# Altium schematic sheet EIT_BLE.SchDoc
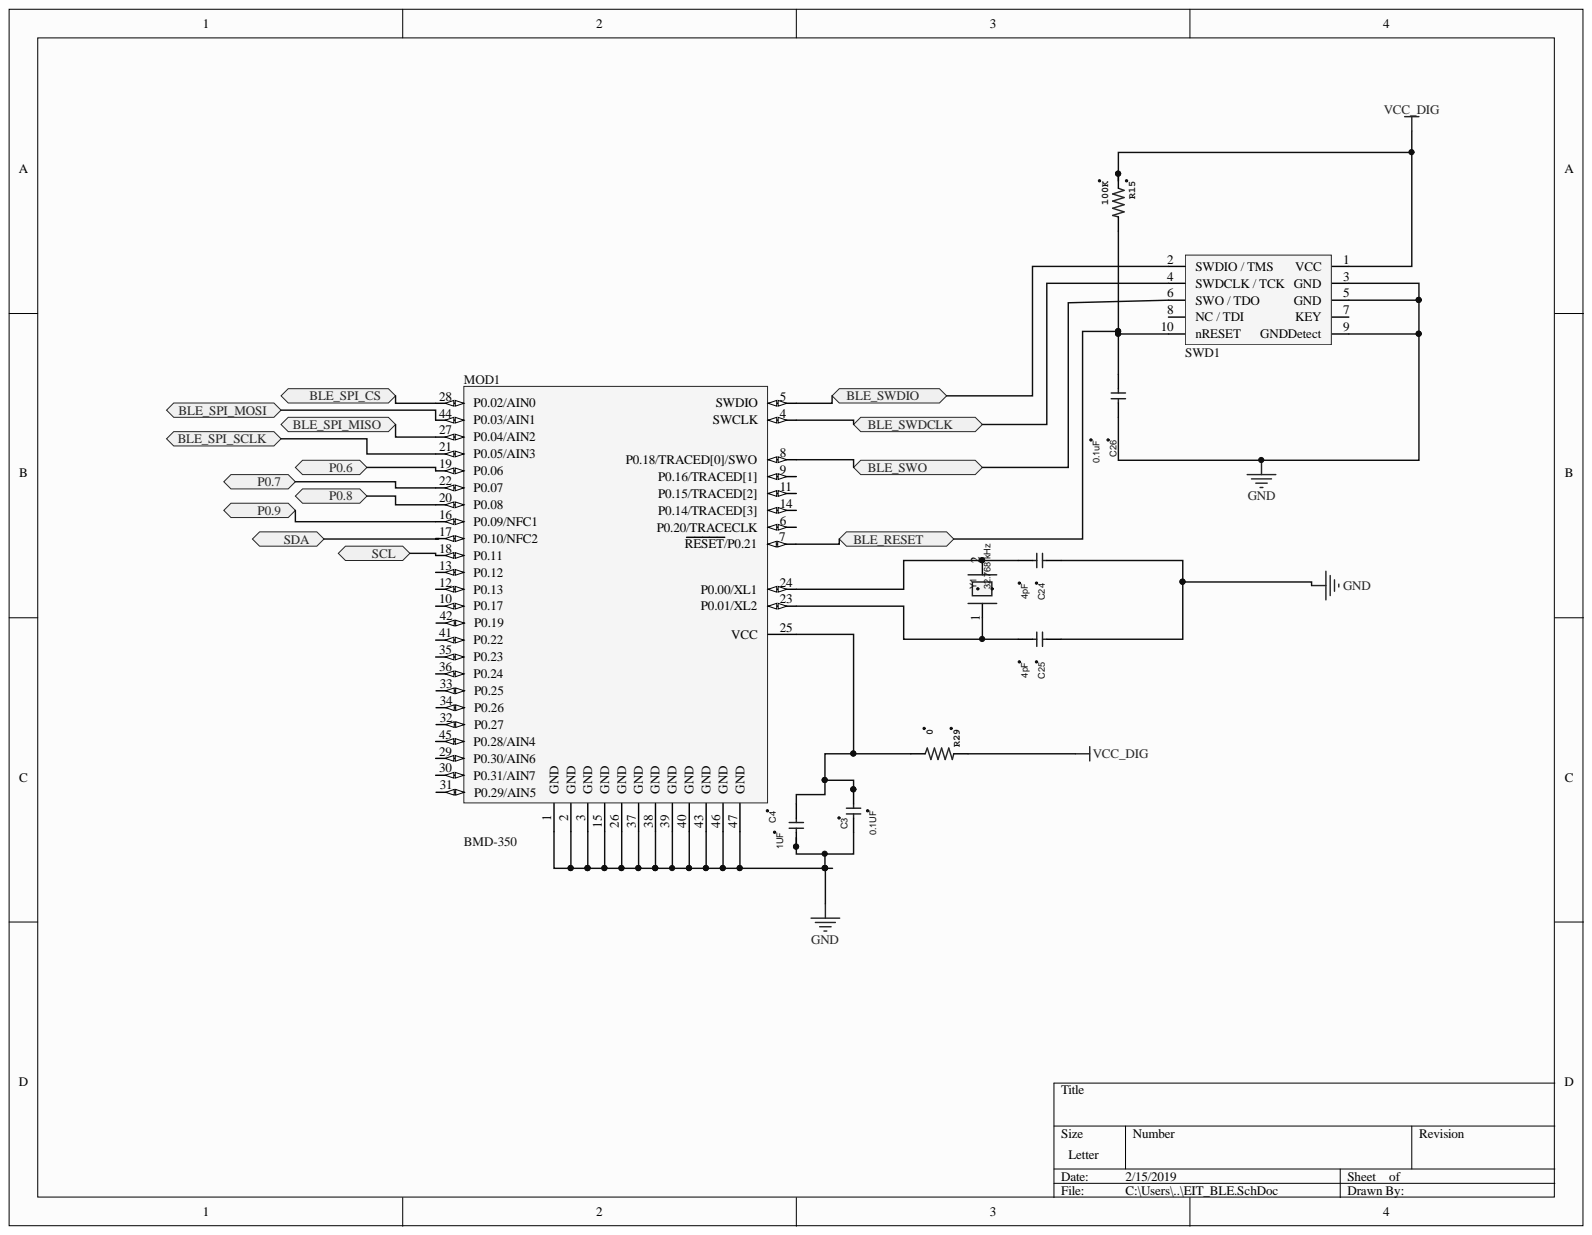
[diagram p0: EIT_BLE.SchDoc]

## Parts
C3  0.1UF  [CAP 0402/1005]
C4  1UF  [CAP 0402/1005]
C24  4pF  [CAP 0402/1005]
C25  4pF  [CAP 0402/1005]
C26  0.1uF  [CAP_0603]
MOD1  BMD-350  [BMD-350]
R15  100K  [RES_1608X55]
R29  0  [RES_1608X55]
SWD1  SWD Header THRU  [2X5-CONN_1.27MM]  (value not drawn)
Y1  32.768 kHz  [XTAL2-2P0X1P2-SM]

## Ports
BLE_RESET, BLE_SPI_CS, BLE_SPI_MISO, BLE_SPI_MOSI, BLE_SPI_SCLK, BLE_SWDCLK, BLE_SWDIO, BLE_SWO, P0.6, P0.7, P0.8, P0.9, SCL, SDA

## Nets
GND: C3.1 C4.1 C24.2 C25.2 C26.1 MOD1.1(GND) MOD1.2(GND) MOD1.3(GND) MOD1.15(GND) MOD1.26(GND) MOD1.37(GND) MOD1.38(GND) MOD1.39(GND) MOD1.40(GND) MOD1.43(GND) MOD1.46(GND) MOD1.47(GND) SWD1.3(GND) SWD1.5(GND) SWD1.9(GNDDetect)
VCC_DIG: R15.2 R29.1 SWD1.1(VCC)
BLE_RESET: C26.2 MOD1.7(RESET/P0.21) R15.1 SWD1.10(nRESET) ; port BLE_RESET
BLE_SPI_CS: MOD1.28(P0.02/AIN0) ; port BLE_SPI_CS
BLE_SPI_MISO: MOD1.27(P0.04/AIN2) ; port BLE_SPI_MISO
BLE_SPI_MOSI: MOD1.44(P0.03/AIN1) ; port BLE_SPI_MOSI
BLE_SPI_SCLK: MOD1.21(P0.05/AIN3) ; port BLE_SPI_SCLK
BLE_SWDCLK: MOD1.4(SWCLK) SWD1.4 ; port BLE_SWDCLK
BLE_SWDIO: MOD1.5(SWDIO) SWD1.2 ; port BLE_SWDIO
BLE_SWO: MOD1.8(P0.18/TRACED[0]/SWO) SWD1.6(SWO_/_TDO) ; port BLE_SWO
P0.6: MOD1.19(P0.06) ; port P0.6
P0.7: MOD1.22(P0.07) ; port P0.7
P0.8: MOD1.20(P0.08) ; port P0.8
P0.9: MOD1.16(P0.09/NFC1) ; port P0.9
SCL: MOD1.18(P0.11) ; port SCL
SDA: MOD1.17(P0.10/NFC2) ; port SDA
NetC3_2: C3.2 C4.2 MOD1.25(VCC) R29.2
NetC24_1: C24.1 MOD1.24(P0.00/XL1) Y1.2
NetC25_1: C25.1 MOD1.23(P0.01/XL2) Y1.1

Not connected: MOD1 6(P0.20/TRACECLK) 9(P0.16/TRACED[1]) 10(P0.17) 11(P0.15/TRACED[2]) 12(P0.13) 13(P0.12) 14(P0.14/TRACED[3]) 29(P0.30/AIN6) 30(P0.31/AIN7) 31(P0.29/AIN5) 32(P0.27) 33(P0.25) 34(P0.26) 35(P0.23) 36(P0.24) 41(P0.22) 42(P0.19) 45(P0.28/AIN4); SWD1 7(KEY) 8(NC_/_TDI)

## Library data of the parts
C3 = CAP_0402_0.1UF: CAP CER 0.1UF 4V X5R 0402 10% tolerance; Manufacturer 1: KEMET; Manufacturer Part Number 1: C0402C104K7PAC7867; Vendor: DIGIKEY; Component Kind: Standard; Supplier 1: Digi-Key; Supplier Part Number 1: 399-14871-1-ND; Value: 0.1UF; Library Name: EIT_lib.SchLib
C4 = CAP_0402_1UF: CAP CER 1UF 4V X5R 0402; Manufacturer 1: TAIYO YUDEN; Manufacturer Part Number 1: AMK105BJ105ME-R; Vendor: DIGIKEY; Component Kind: Standard; Supplier 1: Digi-Key; Supplier Part Number 1: 587-4554-6-ND; Value: 1UF; Library Name: EIT_lib.SchLib
C24 C25 = CAP_0402_4pF: CAP CER 4PF 100V C0G/NP0 0402; Manufacturer 1: SAMSUNG ELETROMECHANICS; Manufacturer Part Number 1: CL05C040CC5NNNC; Vendor: DIGIKEY; Component Kind: Standard; Supplier 1: Digi-Key; Supplier Part Number 1: 1276-6708-6-ND; Value: 4pF; Library Name: EIT_lib.SchLib
C26 = CAP_0603_0.1uF: CAP CER 0.1UF 6.3V 20% X5R 0603; Manufacturer 1: AVX Corporation; Manufacturer Part Number 1: 06036D104KAT2A; Vendor: DIGIKEY; VendorPN: 399-1095-1-ND; Component Kind: Standard; Supplier 1: Digi-Key; Value: 0.1uF; Library Name: EIT_lib.SchLib
MOD1 = BMD-350: Bluetooth 4.2 LE Module, nRF52832, 512kB Flash, 64kB RAM; Manufacture Part Number 1: BMD-350-A-R; Manufacturer 1: Rigado, Inc; Component Kind: Standard; Supplier 1: Digi-Key; Supplier Part Number 1: 1604-1012-6-ND; Library Name: EIT_lib.SchLib
R15 = RES_0603_100K: 100kOhm RES_0603; Manufacturer 1: VISHAY/DALE; Manufacturer Part Number 1: TNPW0603100KBEEA; DeviceName: -0603(1608METRIC); DeviceSetName: RESISTOR-US; GateName_1: G$1; Component Kind: Standard; Supplier 1: Digi-Key; Supplier Part Number 1: TNP100KAADKR-ND; SymbolName_1: RESISTOR-US; Value: 100K; Library Name: EIT_lib.SchLib
R29 = RES_0603_zero: Zero Ohm RES_0603; Manufacturer 1: Panasonic Electronic Components; Manufacturer Part Number 1: ERJ-3GEY0R00V; DeviceName: -0603(1608METRIC); DeviceSetName: RESISTOR-US; GateName_1: G$1; Component Kind: Standard; Supplier 1: Digi-Key; SymbolName_1: RESISTOR-US; Value: 0; Library Name: EIT_lib.SchLib
SWD1 = 10 Pin Jtag Header_THRU: 10 Positions Header Connector 0.050" (1.27mm) Through Hole Gold; Manufacturer 1: CNC Tech; Manufacturer Part Number 1: 3220-10-0100-00; Component Kind: Standard; Supplier 1: Digi-Key; Supplier 2: Digi-Key; Supplier Part Number 2: 1175-1627-ND; Library Name: EIT_lib.SchLib
Y1 = XTAL_32KHz_2x1.2: XTAL 32.768KHZ 4.0pF 20ppm 2.0mm x 1.2mm SMT; Manufacturer 1: ABRACON CORP; Manufacturer Part Number 1: ABS06-107-32.768KHZ-T; Vendor: DIGIKEY; VendorPN: 535-12373-1-ND; Component Kind: Standard; Supplier 1: Digi-Key; Supplier Part Number 1: 535-12373-1-ND; Value: 32.768 kHz; Library Name: EIT_lib.SchLib
